# Altium schematic sheet Sheet2.SchDoc
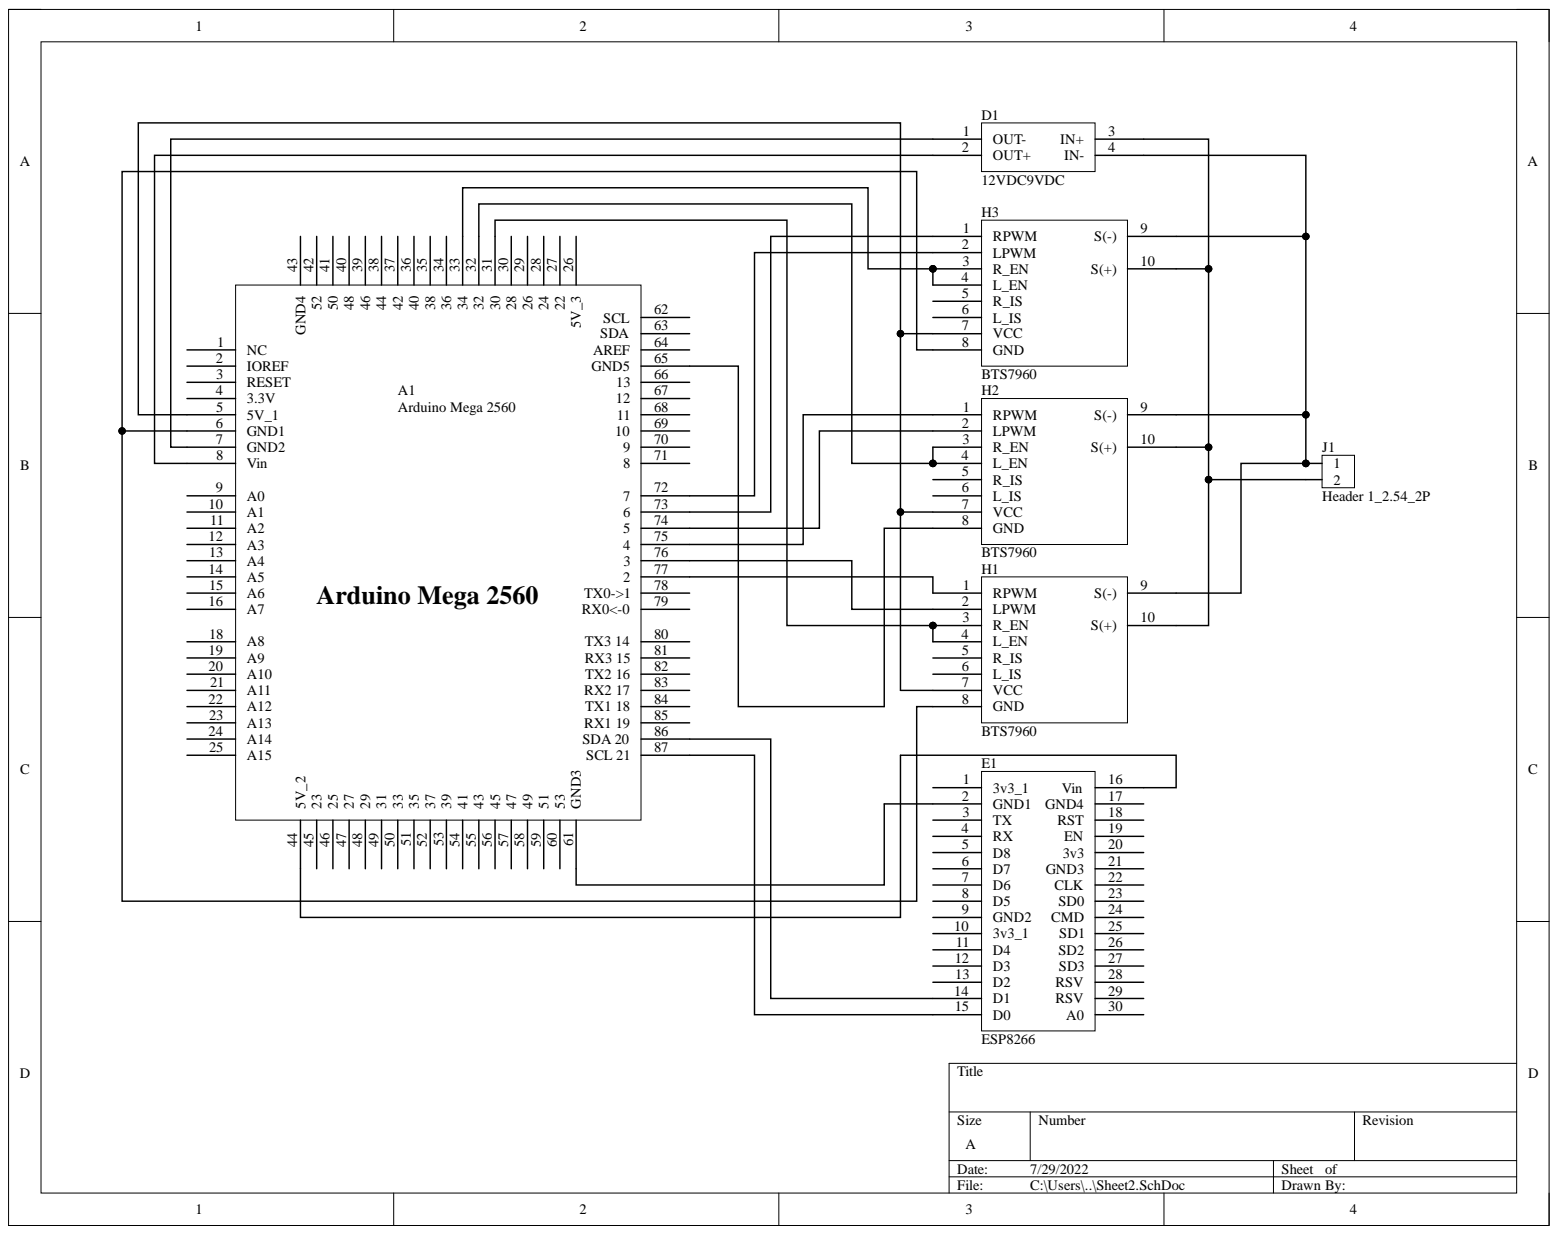
[diagram p0: Sheet2.SchDoc]

## Parts
A1  Arduino Mega 2560  [ArduinoMega2560]
D1  12VDC9VDC  [LM2596]
E1  ESP8266  [ESP8266]
H1  BTS7960  [BTS7960]
H2  BTS7960  [BTS7960]
H3  BTS7960  [BTS7960]
J1  Header 1_2.54_2P  [Header_Duc Cong_2P 2.54]

## Nets
NetA1_5: A1.5(5V_1) H1.7(VCC) H2.7(VCC) H3.7(VCC)
NetA1_6: A1.6(GND1) H1.8(GND) H3.8(GND)
NetA1_7: A1.7(GND2) D1.1(OUT-)
NetA1_8: A1.8(Vin) D1.2(OUT+)
NetA1_31: A1.31 H1.3(R_EN) H1.4(L_EN)
NetA1_32: A1.32 H2.3(R_EN) H2.4(L_EN)
NetA1_33: A1.33 H3.3(R_EN) H3.4(L_EN)
NetA1_44: A1.44(5V_2) E1.16(Vin)
NetA1_61: A1.61(GND3) E1.2(GND1)
NetA1_65: A1.65(GND5) H2.8(GND)
NetA1_72: A1.72 H3.2(LPWM)
NetA1_73: A1.73 H3.1(RPWM)
NetA1_74: A1.74 H2.2(LPWM)
NetA1_75: A1.75 H2.1(RPWM)
NetA1_76: A1.76 H1.2(LPWM)
NetA1_77: A1.77 H1.1(RPWM)
NetA1_86: A1.86(SDA_20) E1.14(D1)
NetA1_87: A1.87(SCL_21) E1.15(D0)
NetD1_3: D1.3(IN+) H1.10(S(+)) H2.10(S(+)) H3.10(S(+)) J1.2
NetD1_4: D1.4(IN-) H1.9(S(-)) H2.9(S(-)) H3.9(S(-)) J1.1

Not connected: A1 1(NC) 2(IOREF) 3(RESET) 4(3.3V) 9(A0) 10 11(A2) 12(A3) 13(A4) 14(A5) 15(A6) 16(A7) 18(A8) 19(A9) 20(A10) 21(A11) 22(A12) 23(A13) 24(A14) 25(A15) 26(5V_3) 27 28 29 30 34 35 36 37 38 39 40 41 42 43(GND4) 45 46 47 48 49 50 51 52 53 54 55 56 57 58 59 60 62(SCL) 63(SDA) 64(AREF) 66 67 68 69 70 71 78(TX0->1) 79(RX0<-0) 80(TX3_14) 81(RX3_15) 82(TX2_16) 83(RX2_17) 84(TX1_18) 85(RX1_19); E1 1(3v3_1) 3(TX) 4(RX) 5(D8) 6(D7) 7(D6) 8(D5) 9(GND2) 10(3v3_1) 11(D4) 12(D3) 13(D2) 17(GND4) 18(RST) 19(EN) 20(3v3) 21(GND3) 22(CLK) 23(SD0) 24(CMD) 25(SD1) 26(SD2) 27(SD3) 28(RSV) 29(RSV) 30(A0); H1 5(R_IS) 6(L_IS); H2 5(R_IS) 6(L_IS); H3 5(R_IS) 6(L_IS)

## Library data of the parts
D1 = Component_1: Component Kind: Standard; Library Name: DC_DC_LM2596.SchLib
J1 = Header 1_2.54_2P: 12VDC; Chung_Loai: Cắm; Component Kind: Standard; Library Name: THU VIEN SCH.SchLib
A1 = Arduino Mega 2560: Component Kind: Standard; Library Name: ArduinoMega2560.SchLib
E1 = ESP8266: Component Kind: Standard; Library Name: ESP8266.SchLib
H1-H3 = BTS7960: Component Kind: Standard; Library Name: BTS7960.SchLib
